# Altium schematic sheet Sheet4.SchDoc(Sheet4)
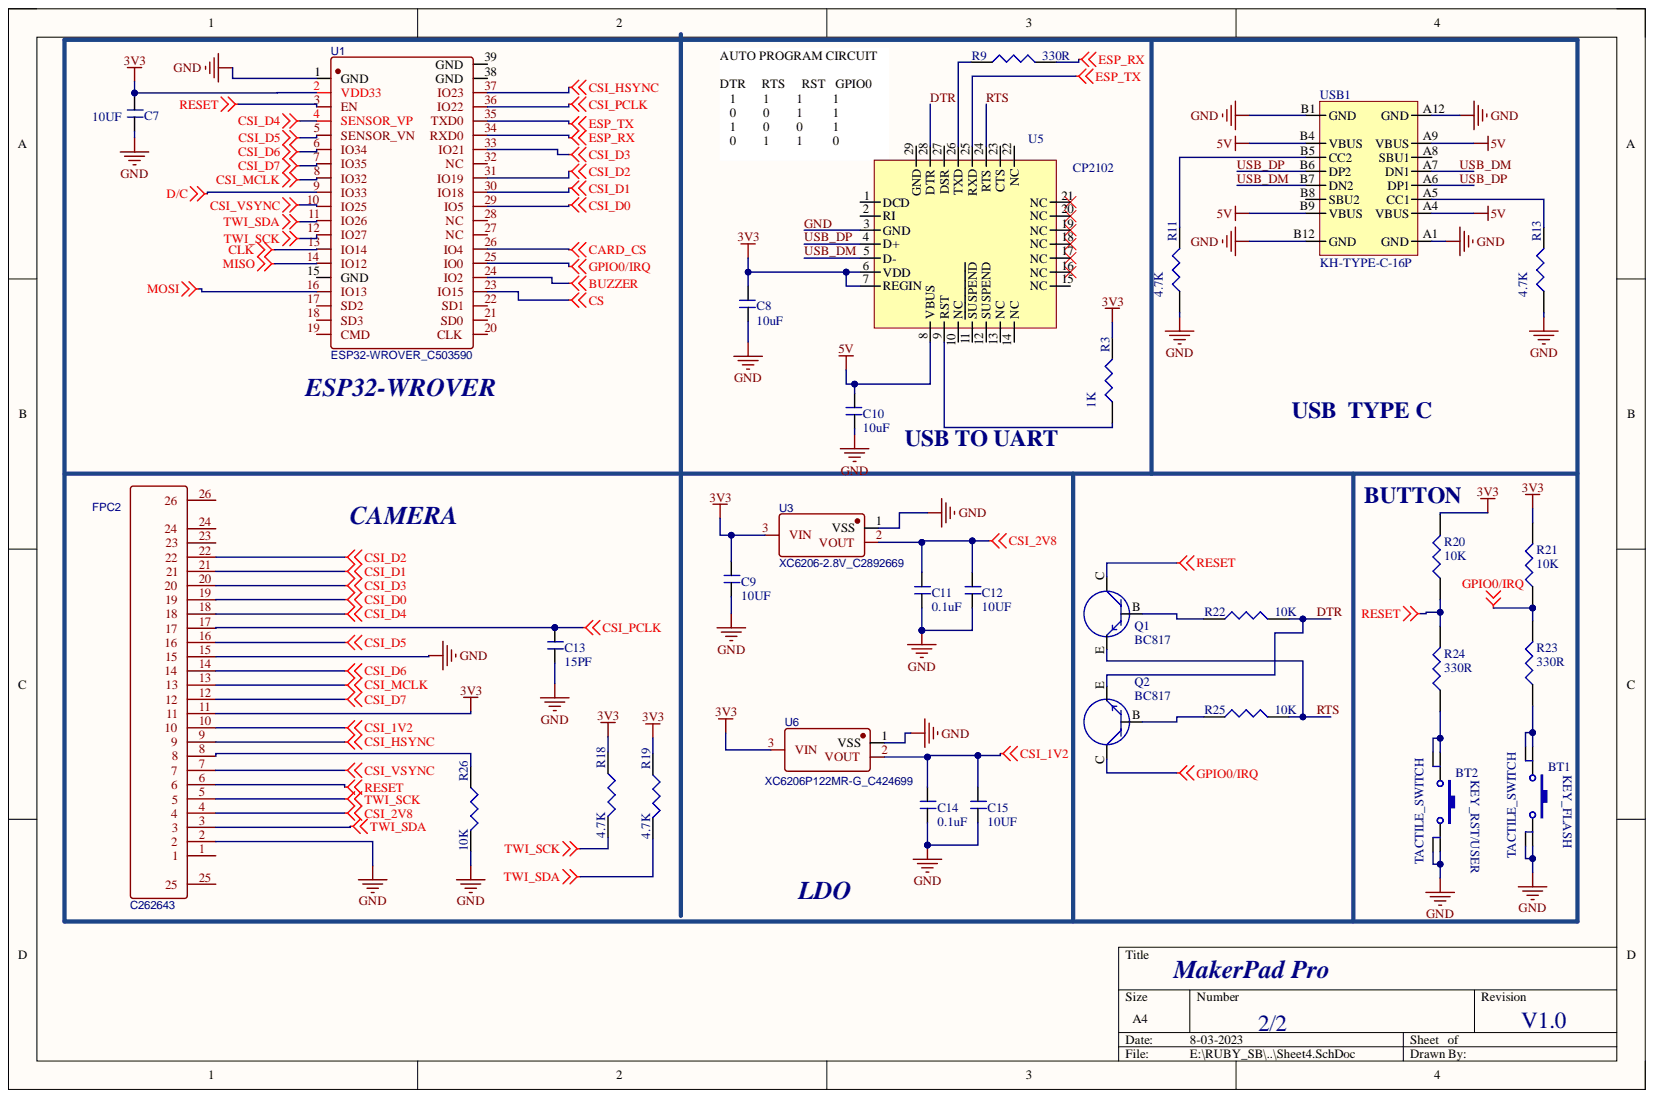
[diagram p0: Sheet4.SchDoc]

## Parts
BT1  TACTILE_SWITCH  [TACT]
BT2  TACTILE_SWITCH  [TACT]
C7  10UF  [C_0603]
C8  10uF  [C_0603]
C9  10UF  [C_0603]
C10  10uF  [C_0603]
C11  0.1uF  [C_0603]
C12  10UF  [C_0603]
C13  15PF  [C_0603]
C14  0.1uF  [C_0603]
C15  10UF  [C_0603]
FPC2  C262643  [FPC_24P-0.5MM/TC]
Q1  BC817  [SOT23]
Q2  BC817  [SOT23]
R3  1K  [R_0603]
R9  330R  [R_0603]
R11  4.7K  [R_0603]
R13  4.7K  [R_0603]
R18  4.7K  [R_0603]
R19  4.7K  [R_0603]
R20  10K  [R_0603]
R21  10K  [R_0603]
R22  10K  [R_0603]
R23  330R  [R_0603]
R24  330R  [R_0603]
R25  10K  [R_0603]
R26  10K  [R_0603]
U1  ESP32-WROVER_C503590  [ESP32-WROVER]
U3  XC6206-2.8V_C2892669  [SOT23 IC]
U5  CP2102  [CP2102]
U6  XC6206P122MR-G_C424699  [SOT23 IC]
USB1  KH-TYPE-C-16P  [USBC-31-M-12]

## Nets
3V3: C7.2 C8.1 C9.2 FPC2.11 R3.2 R18.1 R19.1 R20.2 R21.2 U1.2(VDD33) U3.3 U5.6(VDD) U5.7(REGIN) U6.3
5V: C10.1 U5.8(VBUS) USB1.A4(VBUS) USB1.A9(VBUS) USB1.B4(VBUS) USB1.B9(VBUS)
BUZZER: U1.24(IO2)
CARD_CS: U1.26(IO4)
CLK: U1.13(IO14)
CS: U1.23(IO15)
CSI_1V2: C14.2 C15.2 FPC2.10 U6.2
CSI_2V8: C11.2 C12.2 FPC2.4 U3.2
CSI_D0: FPC2.19 U1.29(IO5)
CSI_D1: FPC2.21 U1.30(IO18)
CSI_D2: FPC2.22 U1.31(IO19)
CSI_D3: FPC2.20 U1.33(IO21)
CSI_D4: FPC2.18 U1.4(SENSOR_VP)
CSI_D5: FPC2.16 U1.5(SENSOR_VN)
CSI_D6: FPC2.14 U1.6(IO34)
CSI_D7: FPC2.12 U1.7(IO35)
CSI_HSYNC: FPC2.9 U1.37(IO23)
CSI_MCLK: FPC2.13 U1.8(IO32)
CSI_PCLK: C13.2 FPC2.17 U1.36(IO22)
CSI_VSYNC: FPC2.7 U1.10(IO25)
D/C: U1.9(IO33)
ESP_RX: R9.2 U1.34(RXD0)
ESP_TX: U1.35(TXD0) U5.25(RXD)
GPIO0/IRQ: Q2.C R21.1 R23.2 U1.25(IO0)
MISO: U1.14(IO12)
MOSI: U1.16(IO13)
RESET: FPC2.6 Q1.C R20.1 R24.2 U1.3(EN)
TWI_SCK: FPC2.5 R18.2 U1.12(IO27)
TWI_SDA: FPC2.3 R19.2 U1.11(IO26)
DTR: Q2.E R22.2 U5.28(DTR)
GND: BT1.3 BT1.4 BT2.3 BT2.4 C7.1 C8.2 C9.1 C10.2 C11.1 C12.1 C13.1 C14.1 C15.1 FPC2.2 FPC2.15 R11.1 R13.1 R26.2 U1.1(GND) U3.1 U5.3(GND) U6.1 USB1.A1(GND) USB1.A12(GND) USB1.B1(GND) USB1.B12(GND)
RTS: Q1.E R25.2 U5.24(RTS)
USB_DM: U5.5(D-) USB1.A7(DN1) USB1.B7(DN2)
USB_DP: U5.4(D+) USB1.A6(DP1) USB1.B6(DP2)
NetBT1_1: BT1.1 BT1.2 R23.1
NetBT2_1: BT2.1 BT2.2 R24.1
NetFPC2_8: FPC2.8 R26.1
NetQ1_B: Q1.B R22.1
NetQ2_B: Q2.B R25.1
NetR3_1: R3.1 U5.9(RST)
NetR9_1: R9.1 U5.26(TXD)
NetR11_2: R11.2 USB1.B5(CC2)
NetR13_2: R13.2 USB1.A5(CC1)

Not connected: FPC2 1 23 24 25 26; U1 15(GND) 17(SD2) 18(SD3) 19(CMD) 20(CLK) 21(SD0) 22(SD1) 27(NC) 28(NC) 32(NC) 38(GND) 39(GND); U5 1(DCD) 2(RI) 10(NC) 11(SUSPEND) 12(SUSPEND) 13(NC) 14(NC) 15(NC) 16(NC) 17(NC) 18(NC) 19(NC) 20(NC) 21(NC) 22(NC) 23(CTS) 27(DSR) 29(GND); USB1 A8(SBU1) B8(SBU2)

## Library data of the parts
C7-C15 = CAP_NONPOLAR: Component Kind: Standard; Library Name: dxp_pasl_lib.IntLib
FPC2 = AFC07-S24ECA-00: BOM_Manufacturer: JS(钜硕电子); Contributor: LCSC; BOM_JLCPCB Part Class: Extended Part; BOM_Manufacturer Part: AFC07-S24ECA-00; BOM_Supplier: LCSC; BOM_Supplier Part: C262643; Component Kind: Standard; spicePre: F; spiceSymbolName: AFC07-S24ECA-00
Q1 Q2 = TRANSISTER_NPN: Component Kind: Standard; Library Name: dxp_pasl_lib.IntLib
R3 R9 R11 R13 R18-R26 = RES: Component Kind: Standard; Library Name: dxp_pasl_lib.IntLib
U1 = ESP32-WROVER_C503590: BOM_Manufacturer: ESPRESSIF 乐鑫; Contributor: LCSC; BOM_JLCPCB Part Class: Extended Part; BOM_Manufacturer Part: ESP32-WROVER; BOM_Supplier: LCSC; BOM_Supplier Part: C503590; Component Kind: Standard; spicePre: U; spiceSymbolName: ESP32-WROVER_C503590; Library Name: MIX.SchLib
U3 U6 = XC6206-3.3V_C3015484: BOM_Manufacturer: YONGYUTAI(永裕泰); Contributor: lcsc; BOM_Manufacturer Part: XC6206-3.3V; BOM_Supplier: LCSC; BOM_Supplier Part: C3015484; Component Kind: Standard; link: https://atta.szlcsc.com/upload/public/pdf/source/20220516/96806819DEDB4F91BFC22B730F045FD3.pdf; spicePre: U; spiceSymbolName: XC6206-3.3V_C3015484
U5 = CP2102_1: QFN, 28-Leads, Body 5.0x5.0mm (max), Pitch 0.50mm, IPC Medium Density; Component Kind: Standard; Library Name: dxp_pasl_lib.IntLib
USB1 = USB TYPE-C KH-TYPE-C-16P: 3A 1 Surface Mount 16 Female -40?~+85? Type-C SMD USB Connectors ROHS #  C709357; Component Kind: Standard; ComponentLink2Description: New link; ComponentLink2URL: https://lcsc.com/product-detail/USB-Connectors_Shenzhen-Kinghelm-Elec-KH-TYPE-C-16P_C709357.html; Supplier 1: LCSC; Supplier Part Number 1: C165948; Library Name: dxp_pasl_lib.IntLib
BT1 BT2 = TACTILE_SWITCH: Component Kind: Standard; Library Name: dxp_pasl_lib.IntLib
